# Altium schematic sheet RPI-TPS-GK - RPI_Module.SchDoc
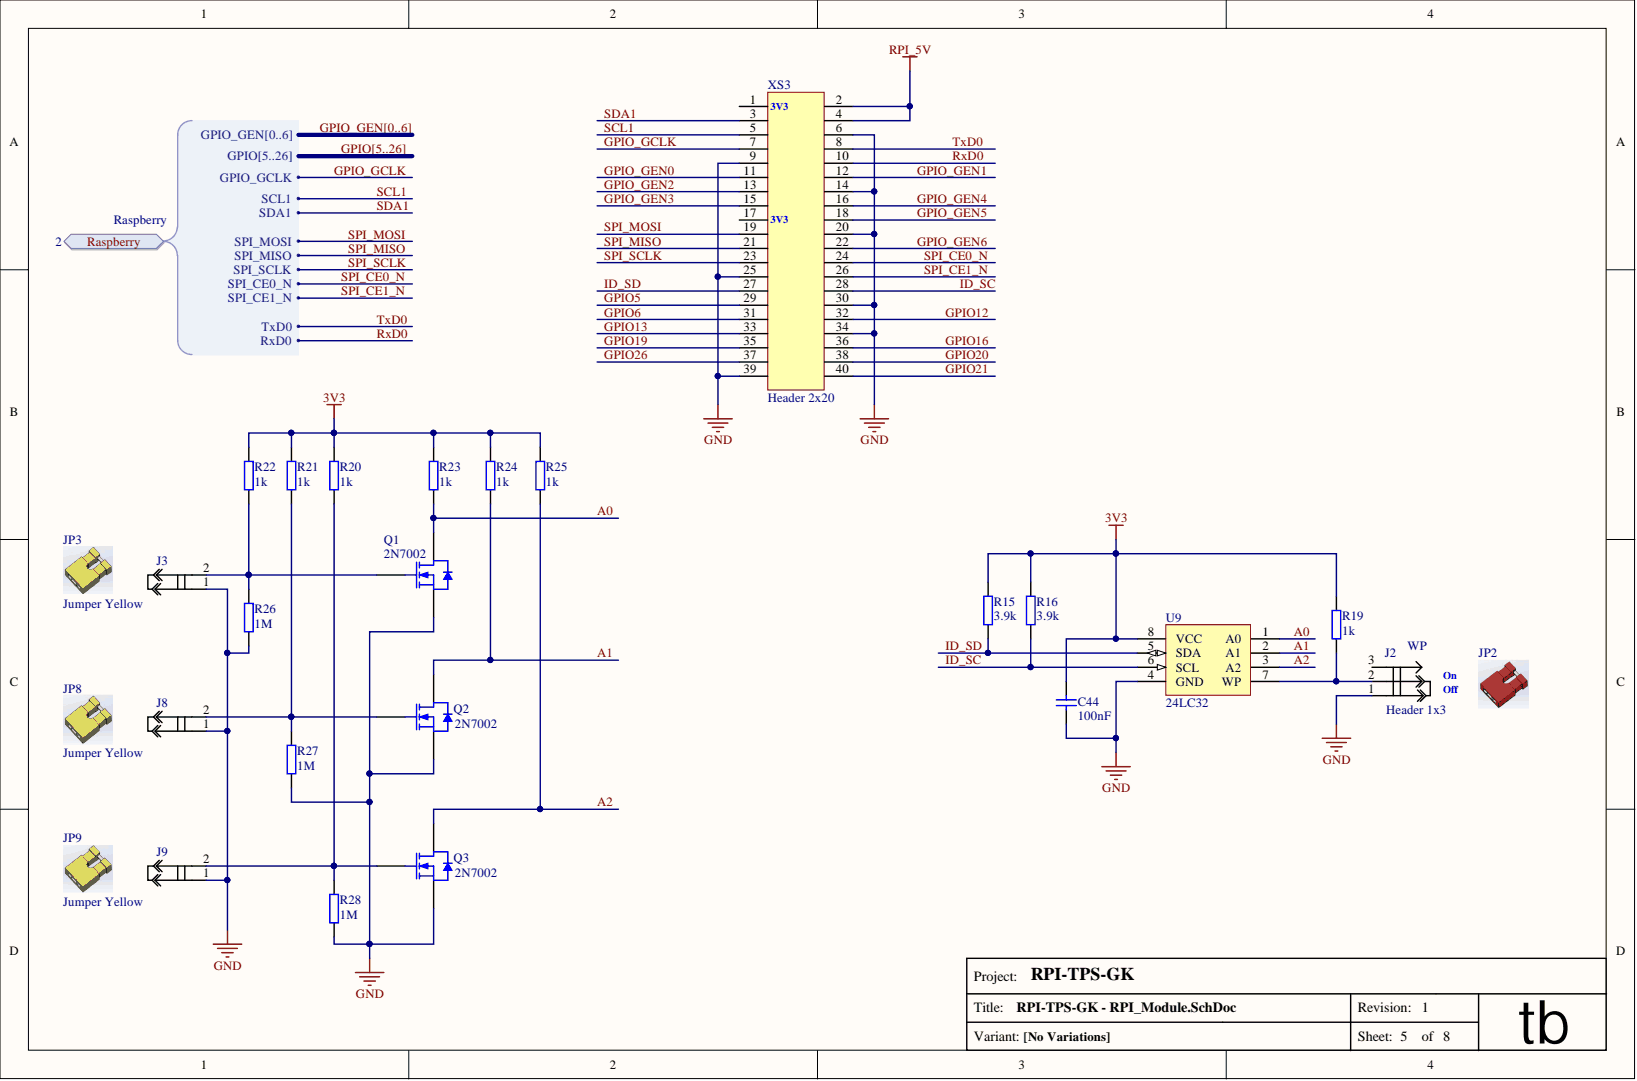
[diagram p0: RPI-TPS-GK - RPI_Module.SchDoc]

## Parts
C44  value 100nF  [C0603]  (hidden comment: CAP)
J2  WP  value Header 1x3  [Jumper_3pin_red]
J3  (no value)  [Jumper_2pin yellow]
J8  (no value)  [Jumper_2pin yellow]
J9  (no value)  [Jumper_2pin yellow]
JP2  (no value)  (mechanical)
JP3  value Jumper Yellow  (hidden comment: VCC_sel)  (mechanical)
JP8  value Jumper Yellow  (hidden comment: VCC_sel)  (mechanical)
JP9  value Jumper Yellow  (hidden comment: VCC_sel)  (mechanical)
Q1  2N7002  [SOT23-3]
Q2  2N7002  [SOT23-3]
Q3  2N7002  [SOT23-3]
R15  value 3.9k  [0603_RESISTOR]  (hidden comment: Resistor)
R16  value 3.9k  [0603_RESISTOR]  (hidden comment: Resistor)
R19  value 1k  [0603_RESISTOR]  (hidden comment: Resistor)
R20  value 1k  [0603_RESISTOR]  (hidden comment: Resistor)
R21  value 1k  [0603_RESISTOR]  (hidden comment: Resistor)
R22  value 1k  [0603_RESISTOR]  (hidden comment: Resistor)
R23  value 1k  [0603_RESISTOR]  (hidden comment: Resistor)
R24  value 1k  [0603_RESISTOR]  (hidden comment: Resistor)
R25  value 1k  [0603_RESISTOR]  (hidden comment: Resistor)
R26  value 1M  [0603_RESISTOR]  (hidden comment: Resistor)
R27  value 1M  [0603_RESISTOR]  (hidden comment: Resistor)
R28  value 1M  [0603_RESISTOR]  (hidden comment: Resistor)
U9  24LC32  [SOIC-8]
XS3  value Header 2x20  Package / Case: Header 2x20  [Conn_RPI_2x20p_2.54]  (hidden comment: Raspberry 2x20)

## Ports
Raspberry, RASPBERRY.GPIO5, RASPBERRY.GPIO6, RASPBERRY.GPIO7, RASPBERRY.GPIO8, RASPBERRY.GPIO9, RASPBERRY.GPIO10, RASPBERRY.GPIO11, RASPBERRY.GPIO12, RASPBERRY.GPIO13, RASPBERRY.GPIO14, RASPBERRY.GPIO15, RASPBERRY.GPIO16, RASPBERRY.GPIO17, RASPBERRY.GPIO18, RASPBERRY.GPIO19, RASPBERRY.GPIO20, RASPBERRY.GPIO21, RASPBERRY.GPIO22, RASPBERRY.GPIO23, RASPBERRY.GPIO24, RASPBERRY.GPIO25, RASPBERRY.GPIO26, Raspberry.GPIO[5..26], Raspberry.GPIO_GCLK, RASPBERRY.GPIO_GEN0, RASPBERRY.GPIO_GEN1, RASPBERRY.GPIO_GEN2, RASPBERRY.GPIO_GEN3, RASPBERRY.GPIO_GEN4, RASPBERRY.GPIO_GEN5, RASPBERRY.GPIO_GEN6, Raspberry.GPIO_GEN[0..6], Raspberry.RxD0, Raspberry.SCL1, Raspberry.SDA1, Raspberry.SPI_CE0_N, Raspberry.SPI_CE1_N, Raspberry.SPI_MISO, Raspberry.SPI_MOSI, Raspberry.SPI_SCLK, Raspberry.TxD0

## Nets
3V3: C44.2 R15.1 R16.1 R19.1 R20.1 R21.1 R22.1 R23.1 R24.1 R25.1 U9.8(VCC)
GND: C44.1 J2.1 J3.1 J8.1 J9.1 Q1.2 Q2.2 Q3.2 R26.1 R27.1 R28.1 U9.4(GND) XS3.6 XS3.9 XS3.14 XS3.20 XS3.25 XS3.30 XS3.34 XS3.39
RPI_5V: XS3.2 XS3.4
A0: Q1.3 R23.2 U9.1(A0)
A1: Q2.3 R24.2 U9.2(A1)
A2: Q3.3 R25.2 U9.3(A2)
GPIO5: XS3.29 ; port Raspberry  [also labelled GPIO]
GPIO6: XS3.31 ; port Raspberry  [also labelled GPIO]
GPIO12: XS3.32 ; port Raspberry  [also labelled GPIO]
GPIO13: XS3.33 ; port Raspberry  [also labelled GPIO]
GPIO16: XS3.36 ; port Raspberry  [also labelled GPIO]
GPIO19: XS3.35 ; port Raspberry  [also labelled GPIO]
GPIO20: XS3.38 ; port Raspberry  [also labelled GPIO]
GPIO21: XS3.40 ; port Raspberry  [also labelled GPIO]
GPIO26: XS3.37 ; port Raspberry  [also labelled GPIO]
GPIO_GCLK: XS3.7 ; port Raspberry
GPIO_GEN0: XS3.11 ; port Raspberry  [also labelled GPIO_GEN]
GPIO_GEN1: XS3.12 ; port Raspberry  [also labelled GPIO_GEN]
GPIO_GEN2: XS3.13 ; port Raspberry  [also labelled GPIO_GEN]
GPIO_GEN3: XS3.15 ; port Raspberry  [also labelled GPIO_GEN]
GPIO_GEN4: XS3.16 ; port Raspberry  [also labelled GPIO_GEN]
GPIO_GEN5: XS3.18 ; port Raspberry  [also labelled GPIO_GEN]
GPIO_GEN6: XS3.22 ; port Raspberry  [also labelled GPIO_GEN]
ID_SC: R16.2 U9.6(SCL) XS3.28
ID_SD: R15.2 U9.5(SDA) XS3.27
RxD0: XS3.10 ; port Raspberry
SCL1: XS3.5 ; port Raspberry
SDA1: XS3.3 ; port Raspberry
SPI_CE0_N: XS3.24 ; port Raspberry
SPI_CE1_N: XS3.26 ; port Raspberry
SPI_MISO: XS3.21 ; port Raspberry
SPI_MOSI: XS3.19 ; port Raspberry
SPI_SCLK: XS3.23 ; port Raspberry
TxD0: XS3.8 ; port Raspberry
NetJ2_2: J2.2 R19.2 U9.7(WP)
NetJ3_2: J3.2 Q1.1 R22.2 R26.2
NetJ8_2: J8.2 Q2.1 R21.2 R27.2
NetJ9_2: J9.2 Q3.1 R20.2 R28.2
GPIO7: ; port Raspberry  [also labelled GPIO]
GPIO8: ; port Raspberry  [also labelled GPIO]
GPIO9: ; port Raspberry  [also labelled GPIO]
GPIO10: ; port Raspberry  [also labelled GPIO]
GPIO11: ; port Raspberry  [also labelled GPIO]
GPIO14: ; port Raspberry  [also labelled GPIO]
GPIO15: ; port Raspberry  [also labelled GPIO]
GPIO17: ; port Raspberry  [also labelled GPIO]
GPIO18: ; port Raspberry  [also labelled GPIO]
GPIO22: ; port Raspberry  [also labelled GPIO]
GPIO23: ; port Raspberry  [also labelled GPIO]
GPIO24: ; port Raspberry  [also labelled GPIO]
GPIO25: ; port Raspberry  [also labelled GPIO]

Not connected: J2 3; XS3 1(3V3) 17(3V3)

## Library data of the parts
C44 = CAP: Manufacturer: Vishay / Vitramon; Manufacturer Part: VJ0603Y104JXJPW1BC; Type of Component: Ceramic Capacitor; Component Kind: Standard; Manufacturer Link: http://www.vishay.com/product?docid=28548; Package / Case: 0603; Supplier 1: aliexpress.com; Supplier 1 Link: aliexpress.com/item/100pcs-lot-100nF-X7R-Error-10-50V-0603-smd-capacitor/32318089455.html?spm=2114.03010208.3.2.PDNk2A&ws_ab_test=searchweb201556_8,searchweb201602_1_10065_10068_112_10069_110_111_418_10017_109_108_10060_10061_10062_10057_10039_10056_10055_10054_10059_10058_10073_10070_10052_10053_10050_10051,searchweb201603_1&btsid=c39062ca-3db8-4562-8db0-c782461646c7; Supplier 2: http://imrad.com.ua/; Supplier 2 Link: http://imrad.com.ua/ru/cc0603krx7r8bb104; Supplier 2 Part: 182925; Supplier 3: http://voron.ua; Supplier 3 Link: http://voron.ua/catalog/001832; Supplier 3 Part: 001832; Library Name: RPI-VITA.IntLib
J2 = jumper_3pin: Type of Component: Header for jumper 3 pin; Component Kind: Standard; Package / Case: Header 1 x 3; Supplier 1: aliexpress.com; Supplier 1 Link: aliexpress.com/item/1Set-20PCS-40Pin-2-54mm-Single-Row-Straight-Male-Pin-Header-Connector-Strip-For-PBC-Ardunio/32329088739.html?spm=2114.03010208.3.2.0ijeiM&ws_ab_test=searchweb201556_1,searchweb201644_1_505_506_503_504_502_10001_10002_10017_10010_10005_10011_10006_10003_10004_10009_10007_10008,searchweb201560_5,searchweb1451318400_-1,searchweb1451318411_6452&btsid=d8fe2734-cbe4-429c-a307-e5400297e31b; Supplier 2: http://imrad.com.ua; Supplier 2 Link: http://imrad.com.ua/ru/pls-40-product-122266; Supplier 2 Part: 202826; Supplier 3: http://voron.ua; Supplier 3 Link: http://voron.ua/catalog/000631; Supplier 3 Part: 000631; Library Name: RPI-VITA.IntLib
J3 J8 J9 = jumper_2pin: Type of Component: Header for jumper 2 pin; Value: Header 1x2; Component Kind: Standard; Package / Case: 2.54mm; Supplier 1: aliexpress.com; Supplier 1 Link: aliexpress.com/item/1Set-20PCS-40Pin-2-54mm-Single-Row-Straight-Male-Pin-Header-Connector-Strip-For-PBC-Ardunio/32329088739.html?spm=2114.03010208.3.2.0ijeiM&ws_ab_test=searchweb201556_1,searchweb201644_1_505_506_503_504_502_10001_10002_10017_10010_10005_10011_10006_10003_10004_10009_10007_10008,searchweb201560_5,searchweb1451318400_-1,searchweb1451318411_6452&btsid=d8fe2734-cbe4-429c-a307-e5400297e31b; Supplier 2: http://imrad.com.ua; Supplier 2 Link: http://imrad.com.ua/ru/pls-40-product-122266; Supplier 2 Part: 202826; Supplier 3: http://voron.ua; Supplier 3 Link: http://voron.ua/catalog/000631; Supplier 3 Part: 000631; Library Name: RPI-VITA.IntLib
JP2 = jumper red: Manufacturer: Great Electric; Manufacturer Part: Jumper Cap Shunts Short Circuit Cap; Type of Component: Jumper; Package / Case: 2.54mm; Supplier 1: aliexpress.com; Supplier 1 Link: http://www.aliexpress.com/item/500pcs-lot-Asssorted-Color-2-54mm-Standard-Circuit-Board-Jumper-Cap-Shunts-Short-Circuit-Cap-CGKCH119/32344305619.html?spm=2114.03010208.3.80.nPPmoy&ws_ab_test=searchweb201556_1,searchweb201644_1_505_506_503_504_502_10001_10002_10017_10010_10005_10011_10006_10003_10004_10009_10007_10008,searchweb201560_5,searchweb1451318400_-1,searchweb1451318411_6452&btsid=16d11777-edde-4755-b8b5-9adacb495b09; Supplier 1 Part: Jumper; Value: Jumper Red; Library Name: RPI-VITA.IntLib
JP3 JP8 JP9 = jumper yellow: Manufacturer: Great Electric; Manufacturer Part: Jumper Cap Shunts Short Circuit Cap; Package / Case: 2.54mm; Supplier 1: aliexpress.com; Supplier 1 Link: http://www.aliexpress.com/item/500pcs-lot-Asssorted-Color-2-54mm-Standard-Circuit-Board-Jumper-Cap-Shunts-Short-Circuit-Cap-CGKCH119/32344305619.html?spm=2114.03010208.3.80.nPPmoy&ws_ab_test=searchweb201556_1,searchweb201644_1_505_506_503_504_502_10001_10002_10017_10010_10005_10011_10006_10003_10004_10009_10007_10008,searchweb201560_5,searchweb1451318400_-1,searchweb1451318411_6452&btsid=16d11777-edde-4755-b8b5-9adacb495b09; Supplier 1 Part: Jumper Yellow; Type of Component: Jumper Yellow; Library Name: RPI-VITA.IntLib
Q1-Q3 = 2N7002: Manufacturer: NXP; Type of Component: Transistor; Component Kind: Standard; Manufacturer Part: 2N7002; Package / Case: SOT23-3; Supplier 1: aliexpress.com; Supplier 2: imrad.com.ua; Supplier 2 Link: http://imrad.com.ua/ru/2n7002-215; Supplier 2 Part: 57705; Supplier 3: voron.ua; Supplier 3 Link: http://voron.ua/catalog/026724; Supplier 3 Part: 026724; Supplier Link 1: aliexpress.com/item/100PCS-2N7002-702-7002-SOT-23-SMD-N-Channel-60V-115mA-MosFET-TOP/32698133537.html?spm=2114.03010208.3.40.eq8Gjj&ws_ab_test=searchweb0_0,searchweb201602_1_10065_10068_10501_10503_10000032_119_10000025_10000029_430_10000028_10060_10062_10056_10055_10000062_10054_10059_10099_10000022_10000012_10103_10000015_10102_10096_10000018_10000019_10000056_10000059_10052_10108_10053_10107_10050_10106_10051_10000053_10000007_10000050_10084_10117_10083_10118_10000047_10080_10082_10081_10110_10111_10112_10113_10114_10115_10000041_10000044_10078_10079_10000038_429_10073_10000035_10121-10503_10501_10078,searchweb201603_1,afswitch_1,single_sort_1_default&btsid=a02df2a7-2963-45a3-8531-a18b2354e564; Supplier Part 1: 2N7002; Value: 2N7002; Library Name: RPI-VITA.IntLib
R15 R16 = Resistor: Manufacturer: UniOhm; Manufacturer Part: 0603 3.9k; Component Kind: Standard; Package / Case: 0603; Supplier 1: aliexpress.com; Supplier 1 Link: aliexpress.com/store/product/0603-SMD-Resistor-1-3-9K-ohm-5000pcs-lot/506373_32308160825.html?storeId=506373&spm=2114.12010208.1000023.14.P6hvHS; Supplier 1 Part: 0603 3.9k; Type of Component: Resistor; Library Name: RPI-VITA.IntLib
R19-R25 = Resistor: Manufacturer: UniOhm; Manufacturer Part: 0603 1k; Component Kind: Standard; Package / Case: 0603; Supplier 1: aliexpress.com; Supplier 1 Link: aliexpress.com/store/product/0603-SMD-Resistor-1-1K-ohm-5000pcs-lot/506373_32306337400.html?storeId=506373&spm=2114.12010208.100007.289.z8ByJf; Supplier 1 Part: 0603 1k; Supplier 2: imrad.com.ua; Supplier 2 Link: http://imrad.com.ua/ru/rc0603jr-071kl; Supplier 2 Part: 182704; Type of Component: Resistor; Library Name: RPI-VITA.IntLib
R26-R28 = Resistor: Manufacturer: UniOhm; Manufacturer Part: 0603 1M; Component Kind: Standard; Package / Case: 0603; Supplier 1: aliexpress.com; Supplier 1 Link: aliexpress.com/store/product/0603-SMD-Resistor-1-3-01K-ohm-5000pcs-lot/506373_32308172298.html?detailNewVersion=&categoryId=400503&spm=2114.12010208.1000023.342.unm836; Supplier 1 Part: 0603 1M; Supplier 2: http://imrad.com.ua/; Supplier 2 Link: http://imrad.com.ua/ru/rc0603fr-071ml; Supplier 2 Part: 186288; Supplier 3: http://voron.ua/; Supplier 3 Link: http://voron.ua/catalog/023267; Supplier 3 Part: 023267; Type of Component: Resistor; Library Name: RPI-VITA.IntLib
U9 = 24LC128: Manufacturer: Microchip Technology; Manufacturer Part: 24LC32A-I/SN; Type of Component: I2C EEPROM; Component Kind: Standard; Manufacturer Link: http://www.microchip.com/wwwproducts/en/24LC32A; Package / Case: SOIC-8; Supplier 1: aliexpress.com; Supplier 1 Link: aliexpress.com/item/Free-Shipping-100pcs-lots-24LC32A-I-SN-24LC32A-24LC32A-ISN-SOP-8-100-New-original-IC/32373924315.html?spm=2114.03010208.3.27.ouUaH4&ws_ab_test=searchweb0_0,searchweb201602_1_10065_10068_10501_10503_10000032_119_10000025_10000029_430_10000028_10060_10062_10056_10055_10000062_10054_10059_10099_10000022_10000012_10103_10000015_10102_10096_10000018_10000019_10000056_10000059_10052_10053_10107_10050_10106_10051_10000053_10000007_10000050_10117_10084_10083_10118_10000047_10080_10082_10081_10110_10111_10112_10113_10114_10115_10000041_10000044_10078_10079_10000038_429_10073_10000035_10121-10503_10501,searchweb201603_1,afswitch_1,single_sort_1_default&btsid=ebddf7a7-46b7-4e56-bba0-087cd307a577; Supplier 1 Part: 24LC32A-I/SN; Supplier 2: http://imrad.com.ua/; Supplier 2 Link: http://imrad.com.ua/ru/24lc32a-i-sn; Supplier 2 Part: 38046; Value: 24LC32; Library Name: RPI-VITA.IntLib
XS3 = conn_RPI_2x20p: Raspberry 2x20 2.54mm Pitch; Type of Component: Connector 2x20 Long; Component Kind: Standard; Supplier 1: aliexpress.com; Supplier 1 Link: aliexpress.com/item/Free-shipping-10-Pcs-Per-Lot-2-54mm-2x20-Pin-Female-Double-Row-Pin-Header-Strip/32537085221.html?spm=2114.03010208.3.47.xzOnrg&ws_ab_test=searchweb201556_10,searchweb201602_2_10034_507_508_10020_10017_10005_10006_10021_10022_10009_10008_10018_10019,searchweb201603_2&btsid=5c2186f6-2696-4b6c-ace2-c997d846beec; Supplier 1 Part: Header 2x20; Library Name: RPI-VITA.IntLib
